# Altium schematic sheet 图4.4(制作完成的声频放大电路).SchDoc
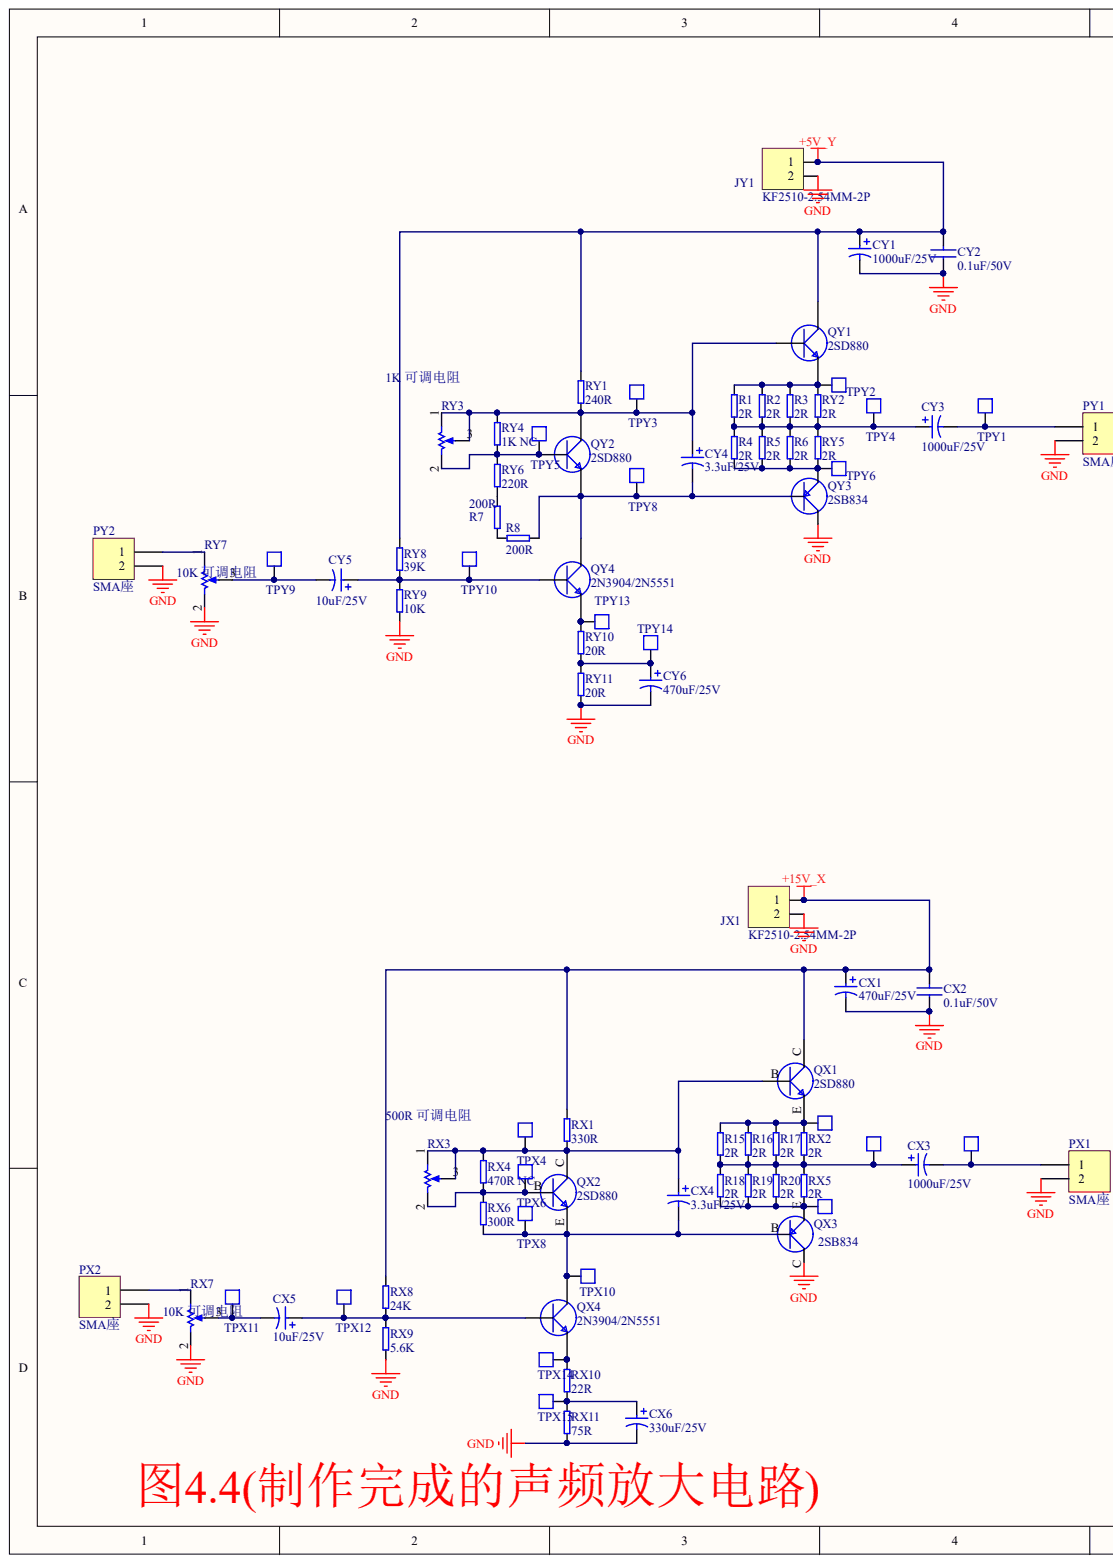
[diagram p0: 图4.4(制作完成的声频放大电路).SchDoc, left]
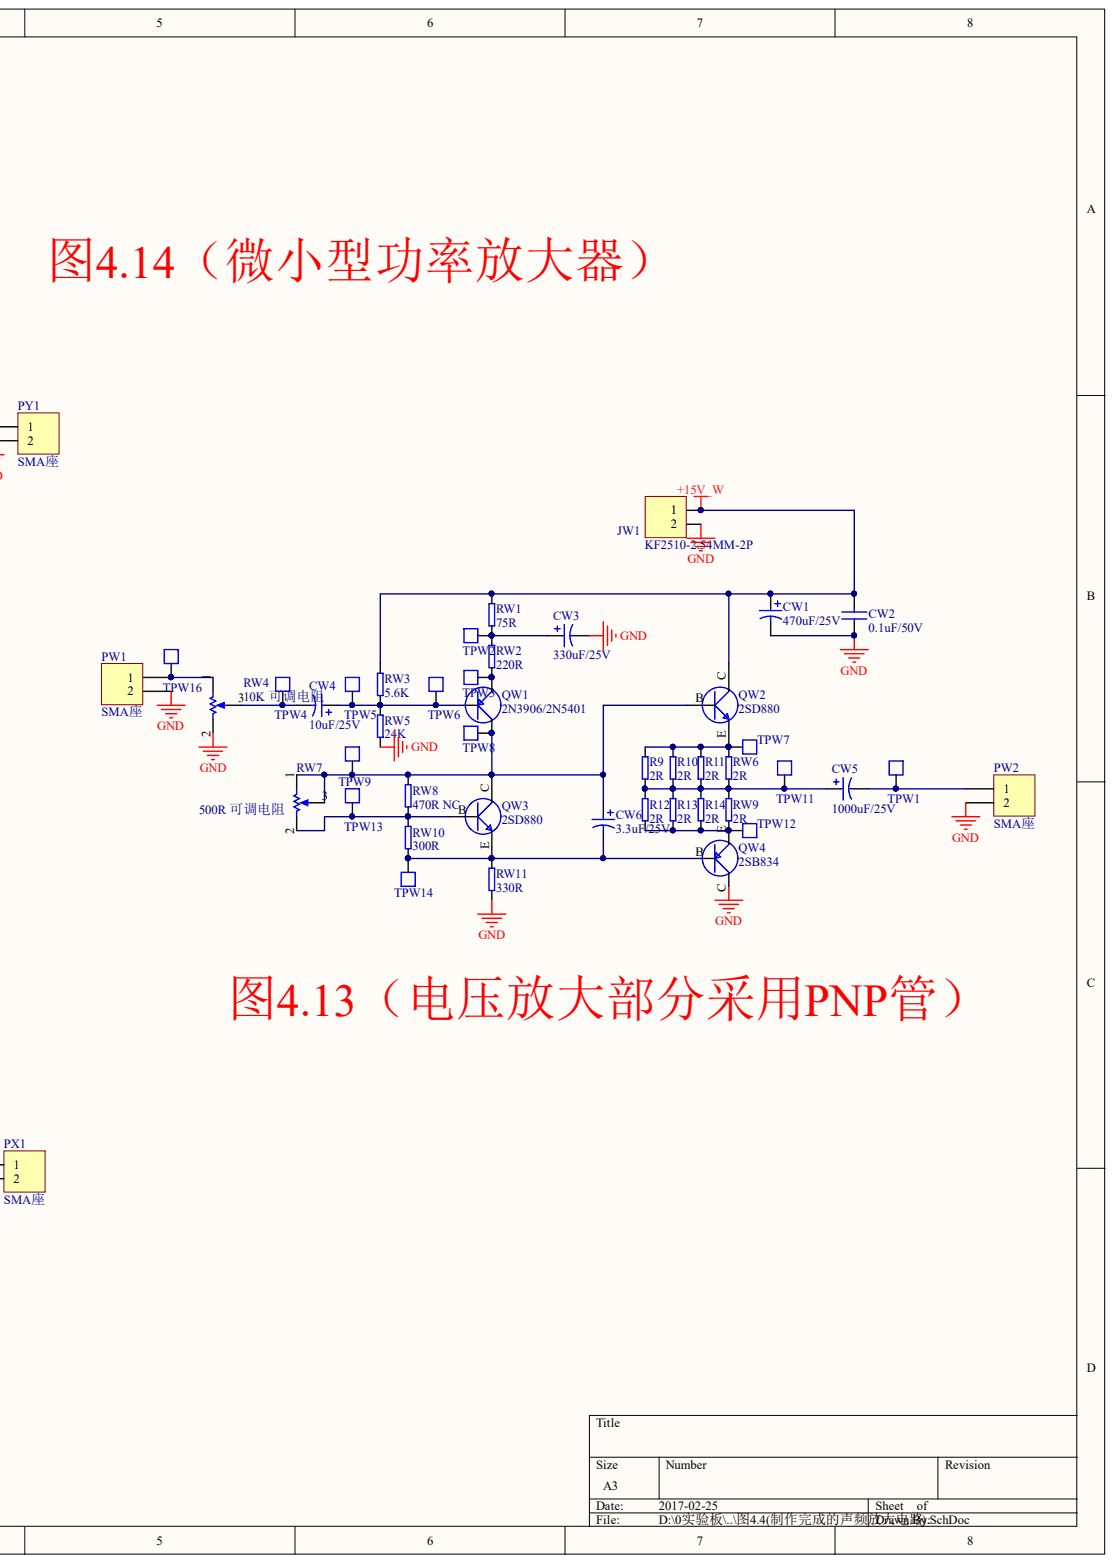
[diagram p1: 图4.4(制作完成的声频放大电路).SchDoc, right]

## Parts
CW1  470uF/25V  [C10045]
CW2  0.1uF/50V  [LC-0805_C]
CW3  330uF/25V  [C10045]
CW4  10uF/25V  [LC-1206_C]
CW5  1000uF/25V  [C10045]
CW6  3.3uF/25V  [C6422]
CX1  470uF/25V  [C10045]
CX2  0.1uF/50V  [LC-0805_C]
CX3  1000uF/25V  [C10045]
CX4  3.3uF/25V  [C6422]
CX5  10uF/25V  [LC-1206_C]
CX6  330uF/25V  [C10045]
CY1  1000uF/25V  [C10045]
CY2  0.1uF/50V  [LC-0805_C]
CY3  1000uF/25V  [C10045]
CY4  3.3uF/25V  [C6422]
CY5  10uF/25V  [LC-1206_C]
CY6  470uF/25V  [C10045]
JW1  KF2510-2.54MM-2P  [KF2510 - 2P - 2.54mm]
JX1  KF2510-2.54MM-2P  [KF2510 - 2P - 2.54mm]
JY1  KF2510-2.54MM-2P  [KF2510 - 2P - 2.54mm]
PW1  SMA座  [ANTENNA - DUPLICATE]
PW2  SMA座  [ANTENNA - DUPLICATE]
PX1  SMA座  [ANTENNA - DUPLICATE]
PX2  SMA座  [ANTENNA - DUPLICATE]
PY1  SMA座  [ANTENNA - DUPLICATE]
PY2  SMA座  [ANTENNA - DUPLICATE]
QW1  2N3906/2N5401  [TO-92- 2N5551]
QW2  2SD880  [TO-220AB(CJ)]
QW3  2SD880  [TO-220AB(CJ)]
QW4  2SB834  [TO-220AB(CJ)]
QX1  2SD880  [TO-220AB(CJ)]
QX2  2SD880  [TO-220AB(CJ)]
QX3  2SB834  [TO-220AB(CJ)]
QX4  2N3904/2N5551  [TO-92- 2N5551]
QY1  2SD880  [TO-220AB(CJ)]
QY2  2SD880  [TO-220AB(CJ)]
QY3  2SB834  [TO-220AB(CJ)]
QY4  2N3904/2N5551  [TO-92- 2N5551]
R1  2R  [LC-0805_R]
R2  2R  [LC-0805_R]
R3  2R  [LC-0805_R]
R4  2R  [LC-0805_R]
R5  2R  [LC-0805_R]
R6  2R  [LC-0805_R]
R7  200R  [LC-0805_R]
R8  200R  [LC-0805_R]
R9  2R  [LC-0805_R]
R10  2R  [LC-0805_R]
R11  2R  [LC-0805_R]
R12  2R  [LC-0805_R]
R13  2R  [LC-0805_R]
R14  2R  [LC-0805_R]
R15  2R  [LC-0805_R]
R16  2R  [LC-0805_R]
R17  2R  [LC-0805_R]
R18  2R  [LC-0805_R]
R19  2R  [LC-0805_R]
R20  2R  [LC-0805_R]
RW1  75R  [LC-0805_R]
RW2  220R  [LC-0805_R]
RW3  5.6K  [LC-0805_R]
RW4  10K 可调电阻  [RP-RES]
RW5  24K  [LC-0805_R]
RW6  2R  [LC-0805_R]
RW7  500R 可调电阻  [RP-RES]
RW8  470R NC  [LC-0805_R]
RW9  2R  [LC-0805_R]
RW10  300R  [LC-0805_R]
RW11  330R  [LC-0805_R]
RX1  330R  [LC-0805_R]
RX2  2R  [LC-0805_R]
RX3  500R 可调电阻  [RP-RES]
RX4  470R NC  [LC-0805_R]
RX5  2R  [LC-0805_R]
RX6  300R  [LC-0805_R]
RX7  10K 可调电阻  [RP-RES]
RX8  24K  [LC-0805_R]
RX9  5.6K  [LC-0805_R]
RX10  22R  [LC-0805_R]
RX11  75R  [LC-0805_R]
RY1  240R  [LC-0805_R]
RY2  2R  [LC-0805_R]
RY3  1K 可调电阻  [RP-RES]
RY4  1K NC  [LC-0805_R]
RY5  2R  [LC-0805_R]
RY6  220R  [LC-0805_R]
RY7  10K 可调电阻  [RP-RES]
RY8  39K  [LC-0805_R]
RY9  10K  [LC-0805_R]
RY10  20R  [LC-0805_R]
RY11  20R  [LC-0805_R]
TPW1  TestPoint  [TP - TEST-OPON]  (value not drawn)
TPW2  TestPoint  [TP - TEST-OPON]  (value not drawn)
TPW3  TestPoint  [TP - TEST-OPON]  (value not drawn)
TPW4  TestPoint  [TP - TEST-OPON]  (value not drawn)
TPW5  TestPoint  [TP - TEST-OPON]  (value not drawn)
TPW6  TestPoint  [TP - TEST-OPON]  (value not drawn)
TPW7  TestPoint  [TP - TEST-OPON]  (value not drawn)
TPW8  TestPoint  [TP - TEST-OPON]  (value not drawn)
TPW9  TestPoint  [TP - TEST-OPON]  (value not drawn)
TPW11  TestPoint  [TP - TEST-OPON]  (value not drawn)
TPW12  TestPoint  [TP - TEST-OPON]  (value not drawn)
TPW13  TestPoint  [TP - TEST-OPON]  (value not drawn)
TPW14  TestPoint  [TP - TEST-OPON]  (value not drawn)
TPW16  TestPoint  [TP - TEST-OPON]  (value not drawn)
TPX3  TestPoint  [TP - TEST-OPON]  (value not drawn)
TPX4  TestPoint  [TP - TEST-OPON]  (value not drawn)
TPX5  TestPoint  [TP - TEST-OPON]  (value not drawn)
TPX6  TestPoint  [TP - TEST-OPON]  (value not drawn)
TPX7  TestPoint  [TP - TEST-OPON]  (value not drawn)
TPX8  TestPoint  [TP - TEST-OPON]  (value not drawn)
TPX9  TestPoint  [TP - TEST-OPON]  (value not drawn)
TPX10  TestPoint  [TP - TEST-OPON]  (value not drawn)
TPX11  TestPoint  [TP - TEST-OPON]  (value not drawn)
TPX12  TestPoint  [TP - TEST-OPON]  (value not drawn)
TPX14  TestPoint  [TP - TEST-OPON]  (value not drawn)
TPX15  TestPoint  [TP - TEST-OPON]  (value not drawn)
TPY1  TestPoint  [TP - TEST-OPON]  (value not drawn)
TPY2  TestPoint  [TP - TEST-OPON]  (value not drawn)
TPY3  TestPoint  [TP - TEST-OPON]  (value not drawn)
TPY4  TestPoint  [TP - TEST-OPON]  (value not drawn)
TPY5  TestPoint  [TP - TEST-OPON]  (value not drawn)
TPY6  TestPoint  [TP - TEST-OPON]  (value not drawn)
TPY8  TestPoint  [TP - TEST-OPON]  (value not drawn)
TPY9  TestPoint  [TP - TEST-OPON]  (value not drawn)
TPY10  TestPoint  [TP - TEST-OPON]  (value not drawn)
TPY13  TestPoint  [TP - TEST-OPON]  (value not drawn)
TPY14  TestPoint  [TP - TEST-OPON]  (value not drawn)

## Nets
+5V_Y: CY1.1 CY2.2 JY1.1 QY1.C RY1.1 RY8.1
+15V_W: CW1.1 CW2.2 JW1.1 QW2.C RW1.1 RW3.1
+15V_X: CX1.1 CX2.2 JX1.1 QX1.C RX1.1 RX8.1
GND: CW1.2 CW2.1 CW3.2 CX1.2 CX2.1 CX6.2 CY1.2 CY2.1 CY6.2 JW1.2 JX1.2 JY1.2 PW1.2 PW2.2 PX1.2 PX2.2 PY1.2 PY2.2 QW4.C QX3.C QY3.C RW4.2 RW5.2 RW11.2 RX7.2 RX9.2 RX11.2 RY7.2 RY9.2 RY11.2
NetCW3_1: CW3.1 RW1.2 RW2.1 TPW2.1
NetCW4_1: CW4.1 QW1.B RW3.2 RW5.1 TPW5.1 TPW6.1
NetCW4_2: CW4.2 RW4.3 TPW4.1
NetCW5_1: CW5.1 R9.2 R10.2 R11.2 R12.1 R13.1 R14.1 RW6.2 RW9.1 TPW11.1
NetCW5_2: CW5.2 PW2.1 TPW1.1
NetCW6_1: CW6.1 QW1.C QW2.B QW3.C RW7.1 RW7.3 RW8.1 TPW8.1 TPW9.1
NetCW6_2: CW6.2 QW3.E QW4.B RW10.2 RW11.1 TPW14.1
NetCX3_1: CX3.1 R15.2 R16.2 R17.2 R18.1 R19.1 R20.1 RX2.2 RX5.1 TPX5.1
NetCX3_2: CX3.2 PX1.1 TPX9.1
NetCX4_1: CX4.1 QX1.B QX2.C RX1.2 RX3.1 RX3.3 RX4.1 TPX4.1
NetCX4_2: CX4.2 QX2.E QX3.B QX4.C RX6.2 TPX8.1 TPX10.1
NetCX5_1: CX5.1 QX4.B RX8.2 RX9.1 TPX12.1
NetCX5_2: CX5.2 RX7.3 TPX11.1
NetCX6_1: CX6.1 RX10.2 RX11.1 TPX15.1
NetCY3_1: CY3.1 R1.2 R2.2 R3.2 R4.1 R5.1 R6.1 RY2.2 RY5.1 TPY4.1
NetCY3_2: CY3.2 PY1.1 TPY1.1
NetCY4_1: CY4.1 QY1.B QY2.C RY1.2 RY3.1 RY3.3 RY4.1 TPY3.1
NetCY4_2: CY4.2 QY2.E QY3.B QY4.C R8.2 TPY8.1
NetCY5_1: CY5.1 QY4.B RY8.2 RY9.1 TPY10.1
NetCY5_2: CY5.2 RY7.3 TPY9.1
NetCY6_1: CY6.1 RY10.2 RY11.1 TPY14.1
NetPW1_1: PW1.1 RW4.1 TPW16.1
NetPX2_1: PX2.1 RX7.1
NetPY2_1: PY2.1 RY7.1
NetQW1_E: QW1.E RW2.2 TPW3.1
NetQW2_E: QW2.E R9.1 R10.1 R11.1 RW6.1 TPW7.1
NetQW3_B: QW3.B RW7.2 RW8.2 RW10.1 TPW13.1
NetQW4_E: QW4.E R12.2 R13.2 R14.2 RW9.2 TPW12.1
NetQX1_E: QX1.E R15.1 R16.1 R17.1 RX2.1 TPX3.1
NetQX2_B: QX2.B RX3.2 RX4.2 RX6.1 TPX6.1
NetQX3_E: QX3.E R18.2 R19.2 R20.2 RX5.2 TPX7.1
NetQX4_E: QX4.E RX10.1 TPX14.1
NetQY1_E: QY1.E R1.1 R2.1 R3.1 RY2.1 TPY2.1
NetQY2_B: QY2.B RY3.2 RY4.2 RY6.1 TPY5.1
NetQY3_E: QY3.E R4.2 R5.2 R6.2 RY5.2 TPY6.1
NetQY4_E: QY4.E RY10.1 TPY13.1
NetR7_1: R7.1 RY6.2
NetR7_2: R7.2 R8.1

## Library data of the parts
CW1 CW3-CW6 CX1 CX3-CX6 CY1 CY3-CY6 = CAP2: TAN; Company: Witium; Project: EP; Component Kind: Standard; Date: 20120609; Simulation: CAP; Created by: Mond.xu
  CW1 CX1 CY6: Value: 470uF/25V
  CW3 CX6: Value: 330uF/25V
  CW4 CX5 CY5: Value: 10uF/25V
  CW5 CX3 CY1 CY3: Value: 1000uF/25V
  CW6 CX4 CY4: Value: 3.3uF/25V
CW2 CX2 CY2 = CAP: Company: Witium; Project: MPU; Component Kind: Standard; Date: 090617; Library Name: Witium_090725.SchLib; Value: 0.1uF/50V; Created by: Mond.Xu
JW1 JX1 JY1 = Header 2: Header, 2-Pin; Company: Witium; Project: EP; Component Kind: Standard; Date: 20120806; Library Name: Miscellaneous Connectors.IntLib; Signal Integrity: Connector; Value: KF2510-2.54MM-2P; Created by: Welkin.Qin
PW1 PW2 PX1 PX2 PY1 PY2 = KF2510-2.54MM-2P: Component Kind: Standard; Description: KF2510-2.54MM-2P; value: SMA座; Library Name: EPC V1.0.SCHLIB
QW1 QW4 QX3 QY3 = MMBT3906: Company: Witium; Project: EP; Component Kind: Standard; Date: 20120614; Library Name: transistor V1.0.SCHLIB; Created by: Mond.Xu
  QW1: Value: 2N3906/2N5401
  QW4 QX3 QY3: Value: 2SB834
QW2 QW3 QX1 QX2 QX4 QY1 QY2 QY4 = S9013SMD: Component Kind: Standard
  QW2 QW3 QX1 QX2 QY1 QY2: Value: 2SD880
  QX4 QY4: Value: 2N3904/2N5551
R1-R20 RW1-RW3 RW5 RW6 RW8-RW11 RX1 RX2 RX4-RX6 RX8-RX11 RY1 RY2 RY4-RY6 RY8-RY11 = Resister: Company: Witium; Project: Remote Stick; Component Kind: Standard; Datetime: 2012-3-30; Library Name: RemoteStick 20120330.SchLib; Created by: Welkin.Qin
  R1-R6 R9-R20 RW6 RW9 RX2 RX5 RY2 RY5: Value: 2R
  R7 R8: Value: 200R
  RW1 RX11: Value: 75R
  RW2 RY6: Value: 220R
  RW3 RX9: Value: 5.6K
  RW5 RX8: Value: 24K
  RW8 RX4: Value: 470R NC
  RW10 RX6: Value: 300R
  RW11 RX1: Value: 330R
  RX10: Value: 22R
  RY1: Value: 240R
  RY4: Value: 1K NC
  RY8: Value: 39K
  RY9: Value: 10K
  RY10 RY11: Value: 20R
RW4 RW7 RX3 RX7 RY3 RY7 = RPot: Potentiometer; Set Position: 0.5; Sim Note: Enter the Resistance value and the set point into parameter fields.; Component Kind: Standard; LatestRevisionDate: 17-Jul-2002; LatestRevisionNote: Re-released for DXP Platform.; Library Name: Miscellaneous Devices.IntLib; PackageReference: VR5; Published: 8-Jun-2000; Publisher: Altium Limited
  RW4 RX7 RY7: Value: 10K 可调电阻
  RW7 RX3: Value: 500R 可调电阻
  RY3: Value: 1K 可调电阻
TPW1-TPW9 TPW11-TPW14 TPW16 TPX3-TPX12 TPX14 TPX15 TPY1-TPY6 TPY8-TPY10 TPY13 TPY14 = TestPoint: From: YoungTown; Component Kind: Standard; Library Name: Witium_20140123.SchLib
